# Altium schematic sheet hellen1-mega-mcu144.SchDoc(hellen1-mega-mcu144)
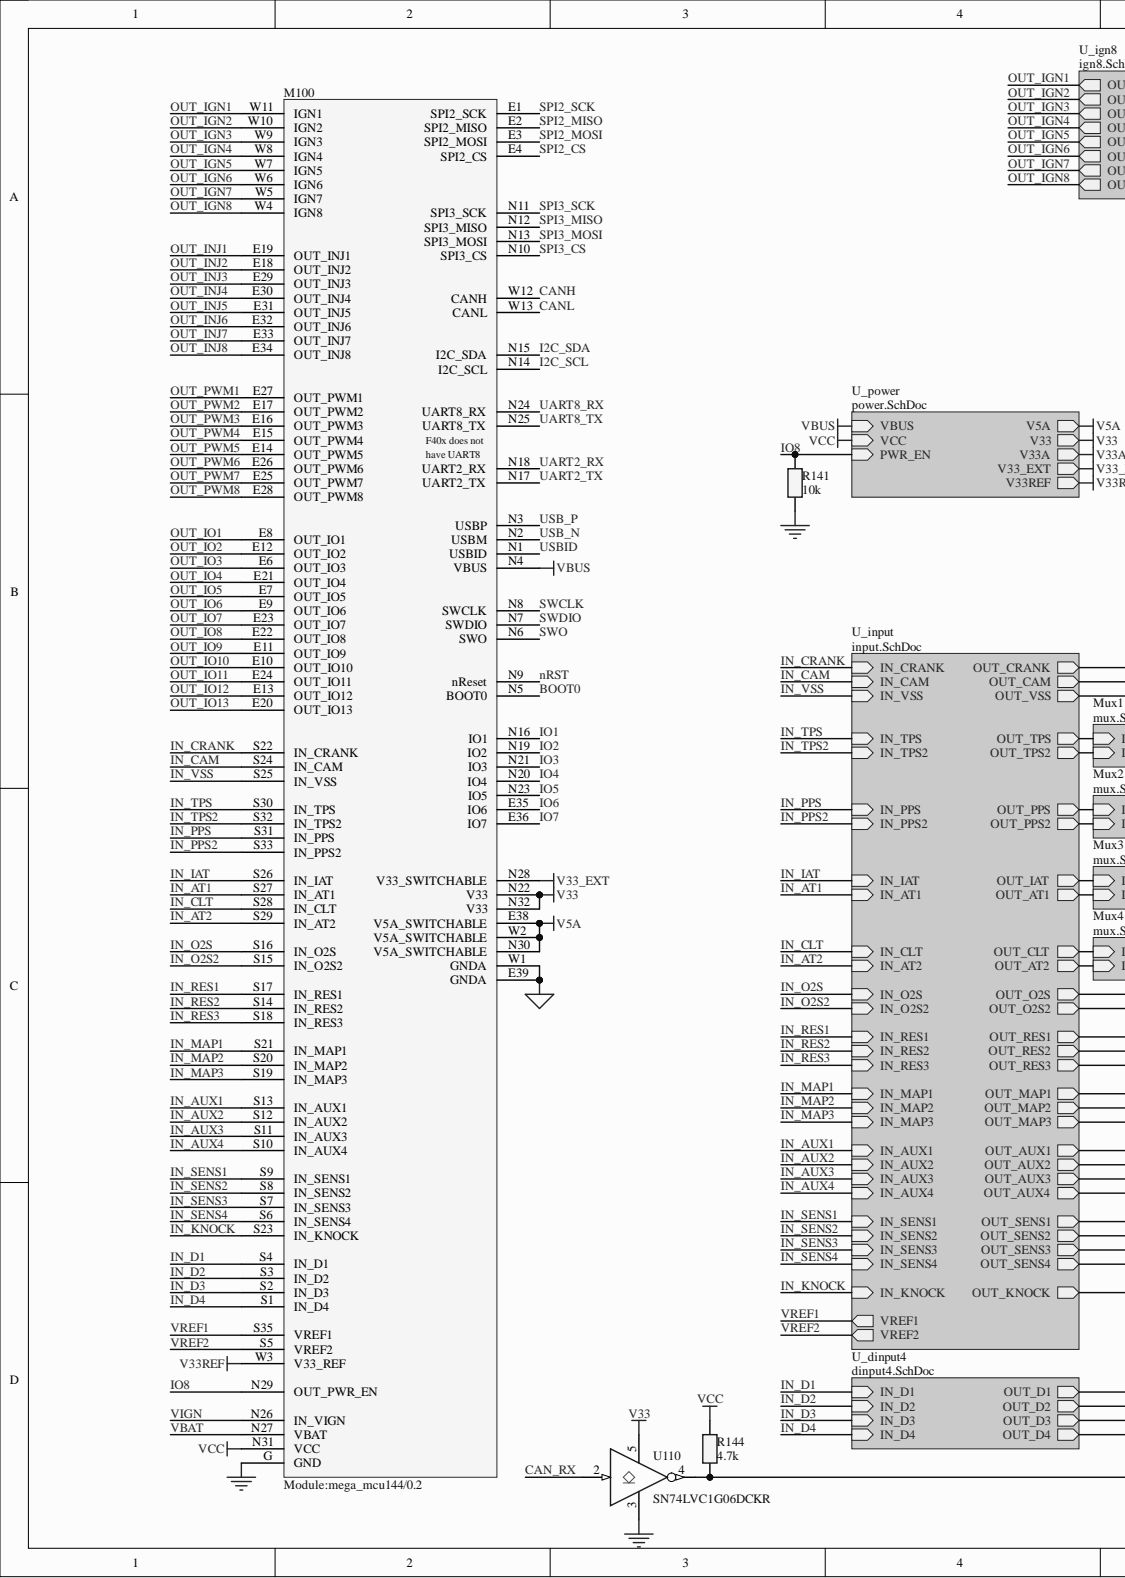
[diagram p0: hellen1-mega-mcu144.SchDoc, left]
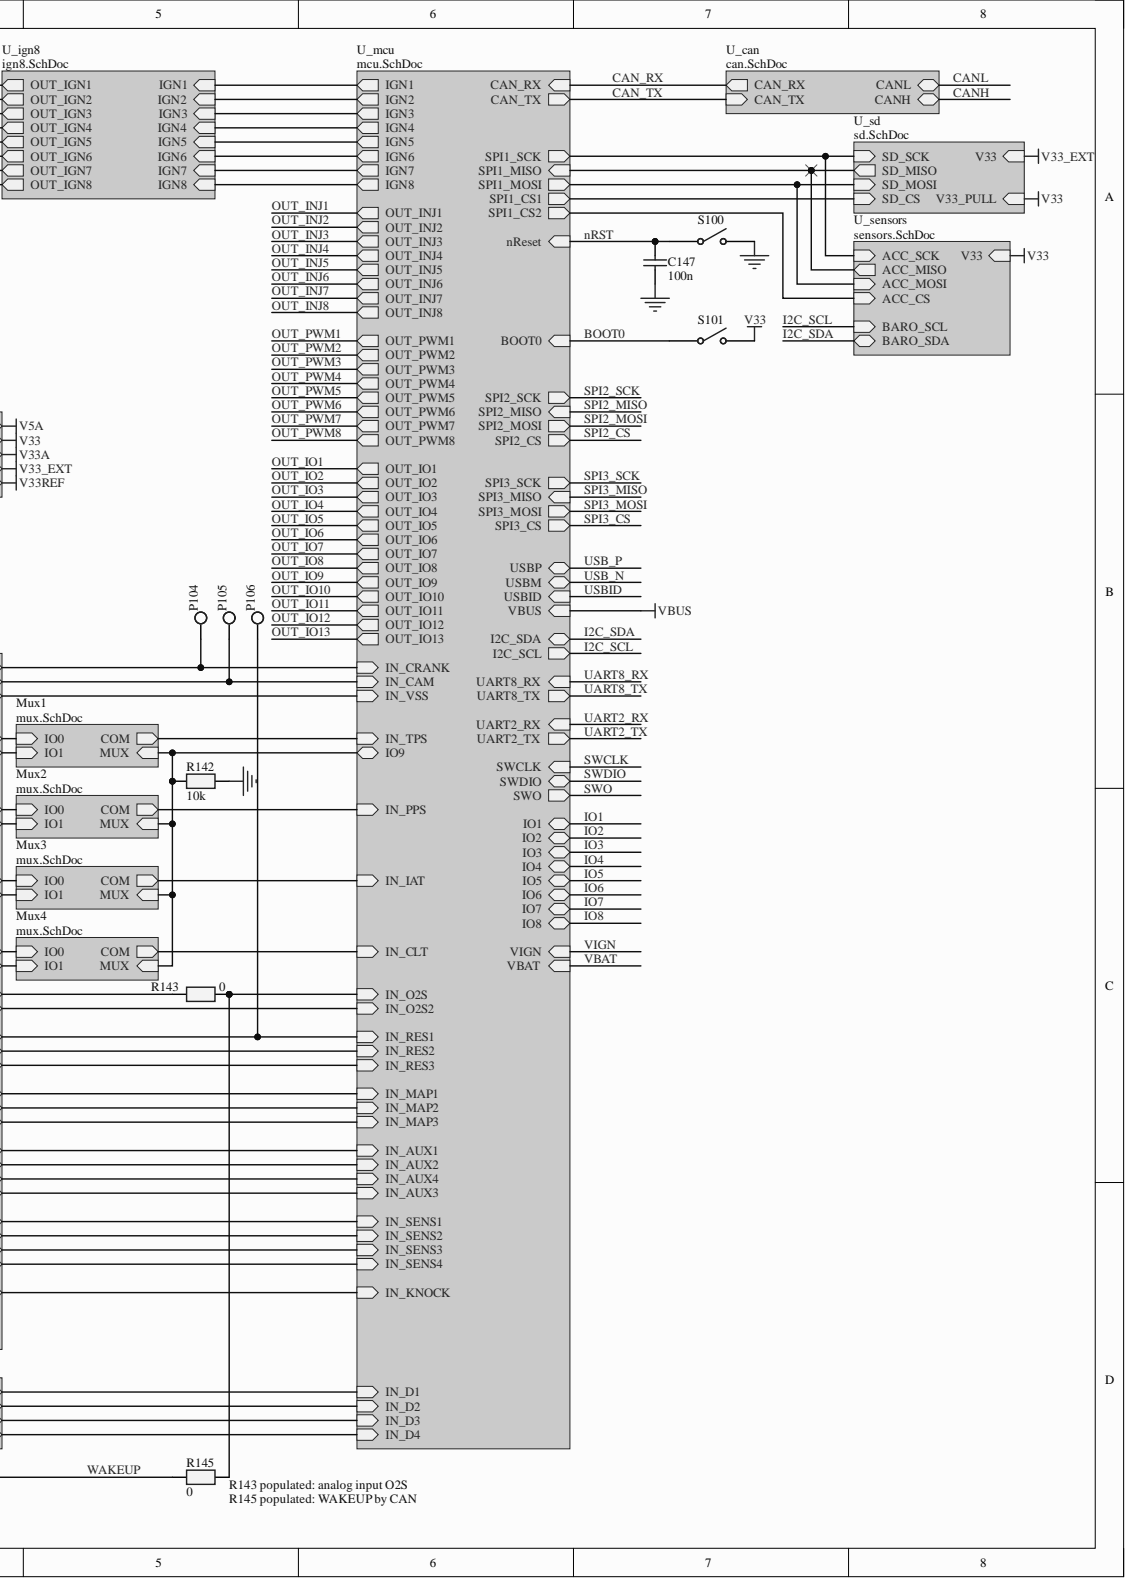
[diagram p1: hellen1-mega-mcu144.SchDoc, right]

## Parts
C147  100n  [C0402HD]
M100  Module:mega_mcu144/0.2  [MOD_Hellen_MEGA_MCU144_0.2]
P104  CRK  [HDR1X1]  (value not drawn)  (no BOM)
P105  CAM  [HDR1X1]  (value not drawn)  (no BOM)
P106  RES1  [HDR1X1]  (value not drawn)  (no BOM)
R141  10k  [R0402]
R142  10k  [R0402]
R143  0  [R0402]
R144  4.7k  [R0402]
R145  0  [R0402]
S100  BTN  [BUTTON-SKRK]  (value not drawn)
S101  BTN  [BUTTON-SKRK]  (value not drawn)
U110  SN74LVC1G06DCKR  [SOT-353]

## Nets
GND: C147.1 M100.G(GND) R141.1 R142.2 S100.2 U110.3
GNDA: M100.E39(GNDA) M100.W1(GNDA)
V5A: M100.E38(V5A_SWITCHABLE) M100.N30(V5A_SWITCHABLE) M100.W2(V5A_SWITCHABLE)
V33: M100.N22(V33) M100.N32(V33) S101.2 U110.5
V33_EXT: M100.N28(V33_SWITCHABLE)
V33REF: M100.W3(V33_REF)
VBUS: M100.N4(VBUS)
VCC: M100.N31(VCC) R144.1
BOOT0: M100.N5(BOOT0) S101.1
CAN_RX: U110.2
CANH: M100.W12(CANH)
CANL: M100.W13(CANL)
I2C_SCL: M100.N14(I2C_SCL)
I2C_SDA: M100.N15(I2C_SDA)
IN_AT1: M100.S27(IN_AT1)
IN_AT2: M100.S29(IN_AT2)
IN_AUX1: M100.S13(IN_AUX1)
IN_AUX2: M100.S12(IN_AUX2)
IN_AUX3: M100.S11(IN_AUX3)
IN_AUX4: M100.S10(IN_AUX4)
IN_CAM: M100.S24(IN_CAM)
IN_CLT: M100.S28(IN_CLT)
IN_CRANK: M100.S22(IN_CRANK)
IN_D1: M100.S4(IN_D1)
IN_D2: M100.S3(IN_D2)
IN_D3: M100.S2(IN_D3)
IN_D4: M100.S1(IN_D4)
IN_IAT: M100.S26(IN_IAT)
IN_KNOCK: M100.S23(IN_KNOCK)
IN_MAP1: M100.S21(IN_MAP1)
IN_MAP2: M100.S20(IN_MAP2)
IN_MAP3: M100.S19(IN_MAP3)
IN_O2S: M100.S16(IN_O2S)
IN_O2S2: M100.S15(IN_O2S2)
IN_PPS: M100.S31(IN_PPS)
IN_PPS2: M100.S33(IN_PPS2)
IN_RES1: M100.S17(IN_RES1)
IN_RES2: M100.S14(IN_RES2)
IN_RES3: M100.S18(IN_RES3)
IN_SENS1: M100.S9(IN_SENS1)
IN_SENS2: M100.S8(IN_SENS2)
IN_SENS3: M100.S7(IN_SENS3)
IN_SENS4: M100.S6(IN_SENS4)
IN_TPS: M100.S30(IN_TPS)
IN_TPS2: M100.S32(IN_TPS2)
IN_VSS: M100.S25(IN_VSS)
IO1: M100.N16(IO1)
IO2: M100.N19(IO2)
IO3: M100.N21(IO3)
IO4: M100.N20(IO4)
IO5: M100.N23(IO5)
IO6: M100.E35(IO6)
IO7: M100.E36(IO7)
IO8: M100.N29(OUT_PWR_EN) R141.2
nRST: C147.2 M100.N9(nReset) S100.1
OUT_IGN1: M100.W11(IGN1)
OUT_IGN2: M100.W10(IGN2)
OUT_IGN3: M100.W9(IGN3)
OUT_IGN4: M100.W8(IGN4)
OUT_IGN5: M100.W7(IGN5)
OUT_IGN6: M100.W6(IGN6)
OUT_IGN7: M100.W5(IGN7)
OUT_IGN8: M100.W4(IGN8)
OUT_INJ1: M100.E19(OUT_INJ1)
OUT_INJ2: M100.E18(OUT_INJ2)
OUT_INJ3: M100.E29(OUT_INJ3)
OUT_INJ4: M100.E30(OUT_INJ4)
OUT_INJ5: M100.E31(OUT_INJ5)
OUT_INJ6: M100.E32(OUT_INJ6)
OUT_INJ7: M100.E33(OUT_INJ7)
OUT_INJ8: M100.E34(OUT_INJ8)
OUT_IO1: M100.E8(OUT_IO1)
OUT_IO2: M100.E12(OUT_IO2)
OUT_IO3: M100.E6(OUT_IO3)
OUT_IO4: M100.E21(OUT_IO4)
OUT_IO5: M100.E7(OUT_IO5)
OUT_IO6: M100.E9(OUT_IO6)
OUT_IO7: M100.E23(OUT_IO7)
OUT_IO8: M100.E22(OUT_IO8)
OUT_IO9: M100.E11(OUT_IO9)
OUT_IO10: M100.E10(OUT_IO10)
OUT_IO11: M100.E24(OUT_IO11)
OUT_IO12: M100.E13(OUT_IO12)
OUT_IO13: M100.E20(OUT_IO13)
OUT_PWM1: M100.E27(OUT_PWM1)
OUT_PWM2: M100.E17(OUT_PWM2)
OUT_PWM3: M100.E16(OUT_PWM3)
OUT_PWM4: M100.E15(OUT_PWM4)
OUT_PWM5: M100.E14(OUT_PWM5)
OUT_PWM6: M100.E26(OUT_PWM6)
OUT_PWM7: M100.E25(OUT_PWM7)
OUT_PWM8: M100.E28(OUT_PWM8)
SPI2_CS: M100.E4(SPI2_CS)
SPI2_MISO: M100.E2(SPI2_MISO)
SPI2_MOSI: M100.E3(SPI2_MOSI)
SPI2_SCK: M100.E1(SPI2_SCK)
SPI3_CS: M100.N10(SPI3_CS)
SPI3_MISO: M100.N12(SPI3_MISO)
SPI3_MOSI: M100.N13(SPI3_MOSI)
SPI3_SCK: M100.N11(SPI3_SCK)
SWCLK: M100.N8(SWCLK)
SWDIO: M100.N7(SWDIO)
SWO: M100.N6(SWO)
UART2_RX: M100.N18(UART2_RX)
UART2_TX: M100.N17(UART2_TX)
UART8_RX: M100.N24(UART8_RX)
UART8_TX: M100.N25(UART8_TX)
USB_N: M100.N2(USBM)
USB_P: M100.N3(USBP)
USBID: M100.N1(USBID)
VBAT: M100.N27(VBAT)
VIGN: M100.N26(IN_VIGN)
VREF1: M100.S35(VREF1)
VREF2: M100.S5(VREF2)
WAKEUP: R144.2 R145.2 U110.4
NetP104_1: P104.1
NetP105_1: P105.1
NetP106_1: P106.1
NetR142_1: R142.1
NetR143_1: R143.1 R145.1
NetR143_2: R143.2
ACC_CS:
ACC_MISO:
ACC_MOSI:
ACC_SCK:
CAN_TX:
COM:
IGN1:
IGN2:
IGN3:
IGN4:
IGN5:
IGN6:
IGN7:
IGN8:
IO0:
SD_CS:
V33A:

## Library data of the parts
C147 = Cap: Capacitor; Fitted: True; Type: SMD; Component Kind: Standard; Library Name: General.schlib; PackageReference: C0402; Signal Integrity: Capacitor; Supplier 3: LCSC; Supplier Part Number 3: C1525
M100 = Mod-Hellen-MEGA_MCU144_0.2: Hellen One MEGA_MCU144 Module; Fitted: False; Component Kind: Standard; Library Name: Mod.SchLib; Supplier 1: Mouser; Supplier 2: Digi-Key; Supplier 3: LCSC; Type: Module; Publisher: qwerty-off
P104-P106 = Pad: Fitted: False; Description: Pad; Library Name: General.schlib
R141-R145 = Res: Resistor; Fitted: True; Component Kind: Standard; Library Name: General.schlib; PackageReference: R0402; Signal Integrity: Resistor; Supplier 3: LCSC; Type: SMD
  R141: PCB3D: 0402; Supplier Part Number 3: C11616
  R142: PCB3D: 0805; Supplier Part Number 3: C11616
  R143 R145: PCB3D: 0805; Supplier Part Number 3: C17168
  R144: PCB3D: 0402; Supplier 1: Mouser; Supplier 2: DigiKey; Supplier Part Number 3: C25900
S100 S101 = Switch SPST: Single-Pole, Single-Throw Switch; Fitted: True; Component Kind: Standard; LatestRevisionDate: 17-Jul-2002; LatestRevisionNote: Re-released for DXP Platform.; Library Name: General.schlib; PackageReference: SMD-2_2.9x3.9x1.7; Published: 24-Mar-1999; Supplier 3: LCSC; Supplier Part Number 3: C115357; Type: SMD; Publisher: Altium Limited
U110 = 74LVC1G06: Component Kind: Standard; Library Name: IC.SchLib; PackageReference: SOT-353; Supplier 1: Mouser; Supplier 2: DigiKey; Supplier 3: LCSC; Supplier Part Number 3: C7828; Type: SMD
